# Altium schematic sheet controller_slave_1.SchDoc(controller_slave_1)
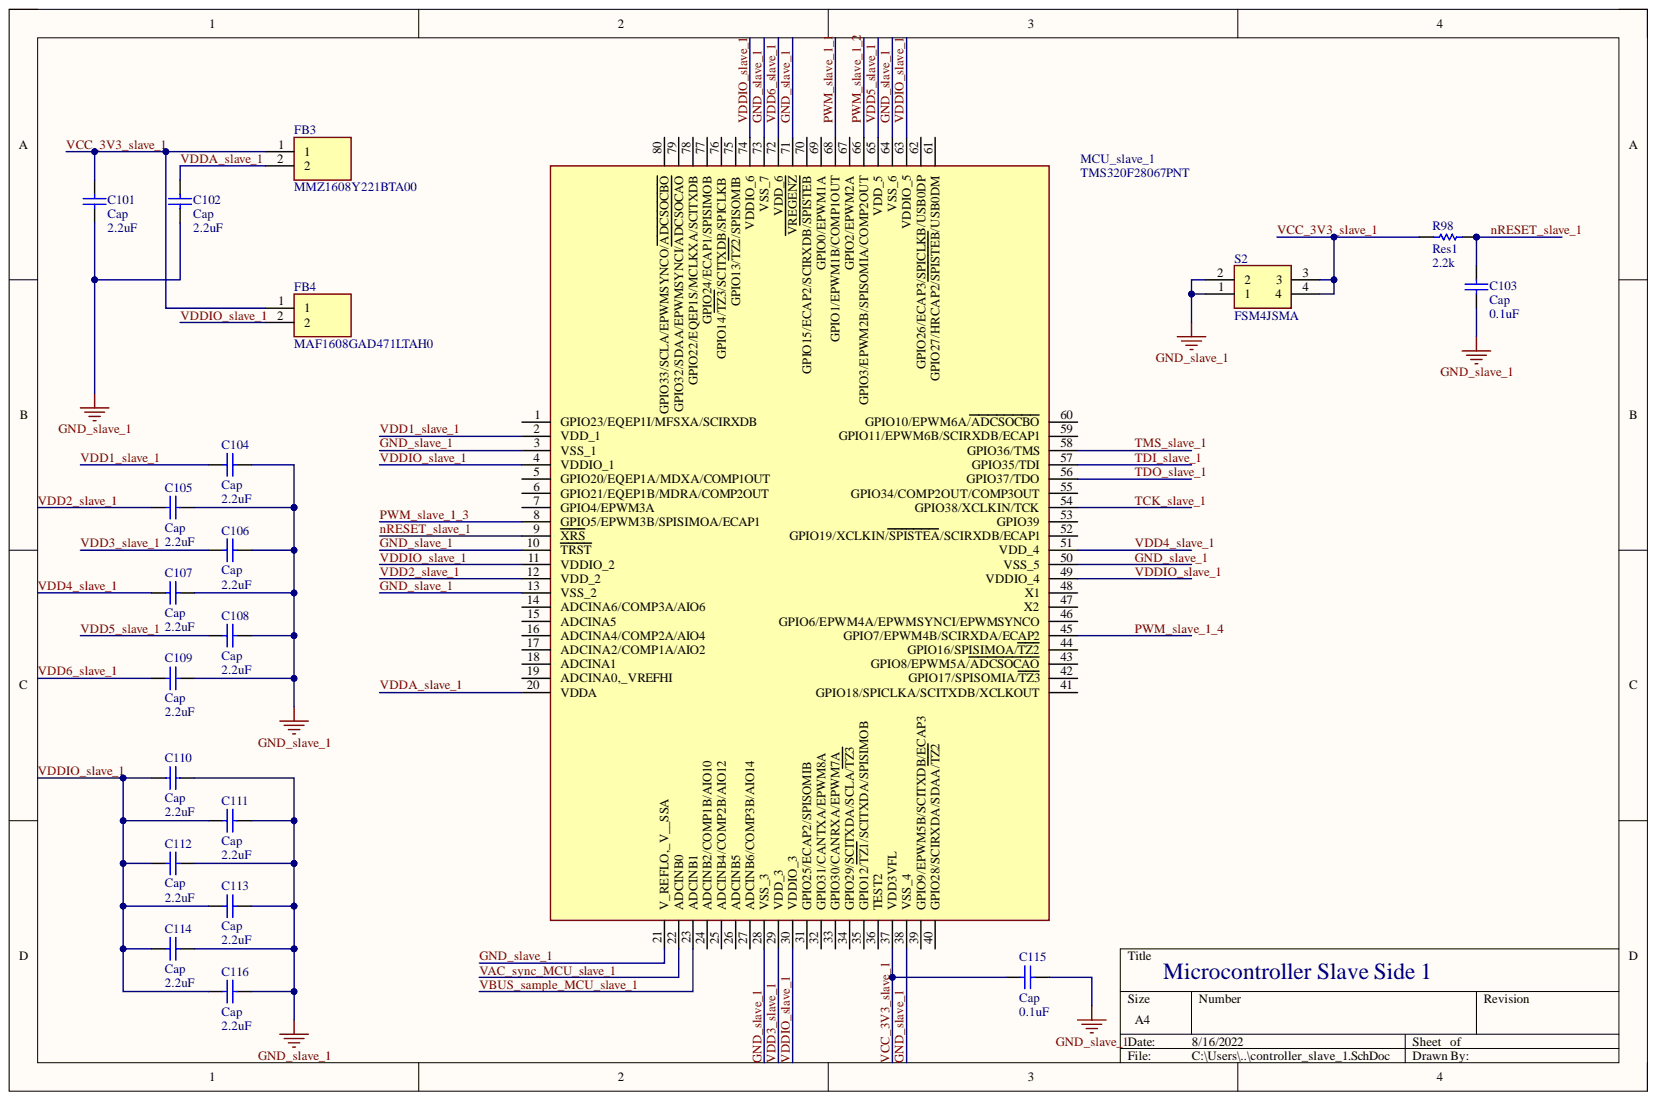
[diagram p0: controller_slave_1.SchDoc]

## Parts
C101  Cap  value 2.2uF  [C0805]
C102  Cap  value 2.2uF  [C0805]
C103  Cap  value 0.1uF  [C0805]
C104  Cap  value 2.2uF  [C0805]
C105  Cap  [C0805]
C106  Cap  value 2.2uF  [C0805]
C107  Cap  value 2.2uF  [C0805]
C108  Cap  value 2.2uF  [C0805]
C109  Cap  value 2.2uF  [C0805]
C110  Cap  value 2.2uF  [C0805]
C111  Cap  value 2.2uF  [C0805]
C112  Cap  value 2.2uF  [C0805]
C113  Cap  value 2.2uF  [C0805]
C114  Cap  value 2.2uF  [C0805]
C115  Cap  value 0.1uF  [C0805]
C116  Cap  value 2.2uF  [C0805]
FB3  MMZ1608Y221BTA00  [BEADC1608X95N]
FB4  MAF1608GAD471LTAH0  [BEADC1608X75N]
MCU_slave_1  TMS320F28067PNT  [QFP50P1400X1400X160-80N]
R98  Res1  value 2.2k  [RES0805W]
S2  FSM4JSMA  [FSM4JSMA]

## Nets
GND_slave_1: C101.2 C102.2 C103.2 C104.1 C105.1 C106.1 C107.1 C108.1 C109.1 C110.1 C111.1 C112.1 C113.1 C114.1 C115.1 C116.1 MCU_slave_1.3(VSS_1) MCU_slave_1.10(TRST) MCU_slave_1.13(VSS_2) MCU_slave_1.21(V_REFLO,_V__SSA) MCU_slave_1.28(VSS_3) MCU_slave_1.38(VSS_4) MCU_slave_1.50(VSS_5) MCU_slave_1.64(VSS_6) MCU_slave_1.71(VREGENZ) MCU_slave_1.73(VSS_7) S2.1 S2.2
nRESET_slave_1: C103.1 MCU_slave_1.9(XRS) R98.2
PWM_slave_1_1: MCU_slave_1.68(GPIO1/EPWM1B/COMP1OUT)
PWM_slave_1_2: MCU_slave_1.66(GPIO3/EPWM2B/SPISOMIA/COMP2OUT)
PWM_slave_1_3: MCU_slave_1.8(GPIO5/EPWM3B/SPISIMOA/ECAP1)
PWM_slave_1_4: MCU_slave_1.45(GPIO7/EPWM4B/SCIRXDA/ECAP2)
TCK_slave_1: MCU_slave_1.54(GPIO38/XCLKIN/TCK)
TDI_slave_1: MCU_slave_1.57(GPIO35/TDI)
TDO_slave_1: MCU_slave_1.56(GPIO37/TDO)
TMS_slave_1: MCU_slave_1.58(GPIO36/TMS)
VAC_sync_MCU_slave_1: MCU_slave_1.22(ADCINB0)
VBUS_sample_MCU_slave_1: MCU_slave_1.23(ADCINB1)
VCC_3V3_slave_1: C101.1 C115.2 FB3.1 FB4.1 MCU_slave_1.37(VDD3VFL) R98.1 S2.3 S2.4
VDD1_slave_1: C104.2 MCU_slave_1.2(VDD_1)
VDD2_slave_1: C105.2 MCU_slave_1.12(VDD_2)
VDD3_slave_1: C106.2 MCU_slave_1.29(VDD_3)
VDD4_slave_1: C107.2 MCU_slave_1.51(VDD_4)
VDD5_slave_1: C108.2 MCU_slave_1.65(VDD_5)
VDD6_slave_1: C109.2 MCU_slave_1.72(VDD_6)
VDDA_slave_1: C102.1 FB3.2 MCU_slave_1.20(VDDA)
VDDIO_slave_1: C110.2 C111.2 C112.2 C113.2 C114.2 C116.2 FB4.2 MCU_slave_1.4(VDDIO_1) MCU_slave_1.11(VDDIO_2) MCU_slave_1.30(VDDIO_3) MCU_slave_1.49(VDDIO_4) MCU_slave_1.63(VDDIO_5) MCU_slave_1.74(VDDIO_6)

Not connected: MCU_slave_1 1(GPIO23/EQEP1I/MFSXA/SCIRXDB) 5(GPIO20/EQEP1A/MDXA/COMP1OUT) 6(GPIO21/EQEP1B/MDRA/COMP2OUT) 7(GPIO4/EPWM3A) 14(ADCINA6/COMP3A/AIO6) 15(ADCINA5) 16(ADCINA4/COMP2A/AIO4) 17(ADCINA2/COMP1A/AIO2) 18(ADCINA1) 19(ADCINA0,_VREFHI) 24(ADCINB2/COMP1B/AIO10) 25(ADCINB4/COMP2B/AIO12) 26(ADCINB5) 27(ADCINB6/COMP3B/AIO14) 31(GPIO25/ECAP2/SPISOMIB) 32(GPIO31/CANTXA/EPWM8A) 33(GPIO30/CANRXA/EPWM7A) 34(GPIO29/SCITXDA/SCLA/TZ3) 35(GPIO12/TZ1/SCITXDA/SPISIMOB) 36(TEST2) 39(GPIO9/EPWM5B/SCITXDB/ECAP3) 40(GPIO28/SCIRXDA/SDAA/TZ2) 41(GPIO18/SPICLKA/SCITXDB/XCLKOUT) 42(GPIO17/SPISOMIA/TZ3) 43(GPIO8/EPWM5A/ADCSOCAO) 44(GPIO16/SPISIMOA/TZ2) 46(GPIO6/EPWM4A/EPWMSYNCI/EPWMSYNCO) 47(X2) 48(X1) 52(GPIO19/XCLKIN/SPISTEA/SCIRXDB/ECAP1) 53(GPIO39) 55(GPIO34/COMP2OUT/COMP3OUT) 59(GPIO11/EPWM6B/SCIRXDB/ECAP1) 60(GPIO10/EPWM6A/ADCSOCBO) 61(GPIO27/HRCAP2/SPISTEB/USB0DM) 62(GPIO26/ECAP3/SPICLKB/USB0DP) 67(GPIO2/EPWM2A) 69(GPIO0/EPWM1A) 70(GPIO15/ECAP2/SCIRXDB/SPISTEB) 75(GPIO13/TZ2/SPISOMIB) 76(GPIO14/TZ3/SCITXDB/SPICLKB) 77(GPIO24/ECAP1/SPISIMOB) 78(GPIO22/EQEP1S/MCLKXA/SCITXDB) 79(GPIO32/SDAA/EPWMSYNCI/ADCSOCAO) 80(GPIO33/SCLA/EPWMSYNCO/ADCSOCBO)

## Library data of the parts
C101-C104 C106 C108 C109 C111 C113 C115 C116 = Cap: Capacitor; Component Kind: Standard; LatestRevisionDate: 17-Jul-2002; LatestRevisionNote: Re-released for DXP Platform.; Library Name: Miscellaneous Devices.IntLib; PackageDescription: Radial Cap, Thru-Hole; 2 Leads; 0.3 in Pin Spacing; PackageReference: RAD-0.3; Published: 8-Jun-2000; Signal Integrity: Cap; Simulation: CAP; Publisher: Altium Limited
C105 C107 C110 C112 C114 = Cap: Capacitor; Value: 2.2uF; Component Kind: Standard; LatestRevisionDate: 17-Jul-2002; LatestRevisionNote: Re-released for DXP Platform.; Library Name: Miscellaneous Devices.IntLib; PackageDescription: Radial Cap, Thru-Hole; 2 Leads; 0.3 in Pin Spacing; PackageReference: RAD-0.3; Published: 8-Jun-2000; Signal Integrity: Cap; Simulation: CAP; Publisher: Altium Limited
FB3 = MMZ1608Y221BTA00: Ferrite Bead; Manufacturer_Name: TDK; Component Kind: Standard; Datasheet Link: https://product.tdk.com/system/files/dam/doc/product/emc/emc/beads/catalog/beads_commercial_signal_mmz1608_en.pdf; Height: 0.95mm; Manufacturer_Part_Number: MMZ1608Y221BTA00
FB4 = MAF1608GAD471LTAH0: Filter; Manufacturer_Name: TDK; Component Kind: Standard; Datasheet Link: https://componentsearchengine.com//MAF1608GAD471LTAH0.pdf; Height: 0.75mm; Manufacturer_Part_Number: MAF1608GAD471LTAH0; Mouser2 Part Number: 810-MAF1608GAD471LTA; Mouser2 Price/Stock: https://www.mouser.com/Search/Refine.aspx?Keyword=810-MAF1608GAD471LTA
MCU_slave_1 = TMS320F28067PNT: Integrated Circuit; Manufacturer_Name: Texas Instruments; Component Kind: Standard; Datasheet Link: http://www.ti.com/lit/gpn/TMS320F28067; Height: 1.6mm; Manufacturer_Part_Number: TMS320F28067PNT
R98 = Res1: Resistor; Value: 2.2k; Component Kind: Standard; LatestRevisionDate: 17-Jul-2002; LatestRevisionNote: Re-released for DXP Platform.; Library Name: Miscellaneous Devices.IntLib; PackageDescription: Axial Device, Thru-Hole; 2 Leads; 0.3 in Pin Spacing; PackageReference: AXIAL-0.3; Published: 8-Jun-2000; Signal Integrity: Res; Simulation: RESISTOR; Publisher: Altium Limited
S2 = FSM4JSMA: Switch; Manufacturer_Name: TE Connectivity; Component Kind: Standard; Datasheet Link: https://componentsearchengine.com//FSM4JSMA.pdf; Height: 5.25mm; Library Name: FSM4JSMA.SchLib; Manufacturer_Part_Number: FSM4JSMA; Mouser2 Part Number: 506-FSM4JSMA; Mouser2 Price/Stock: https://www.mouser.com/Search/Refine.aspx?Keyword=506-FSM4JSMA
